# Altium schematic sheet Real Time Clock.SchDoc
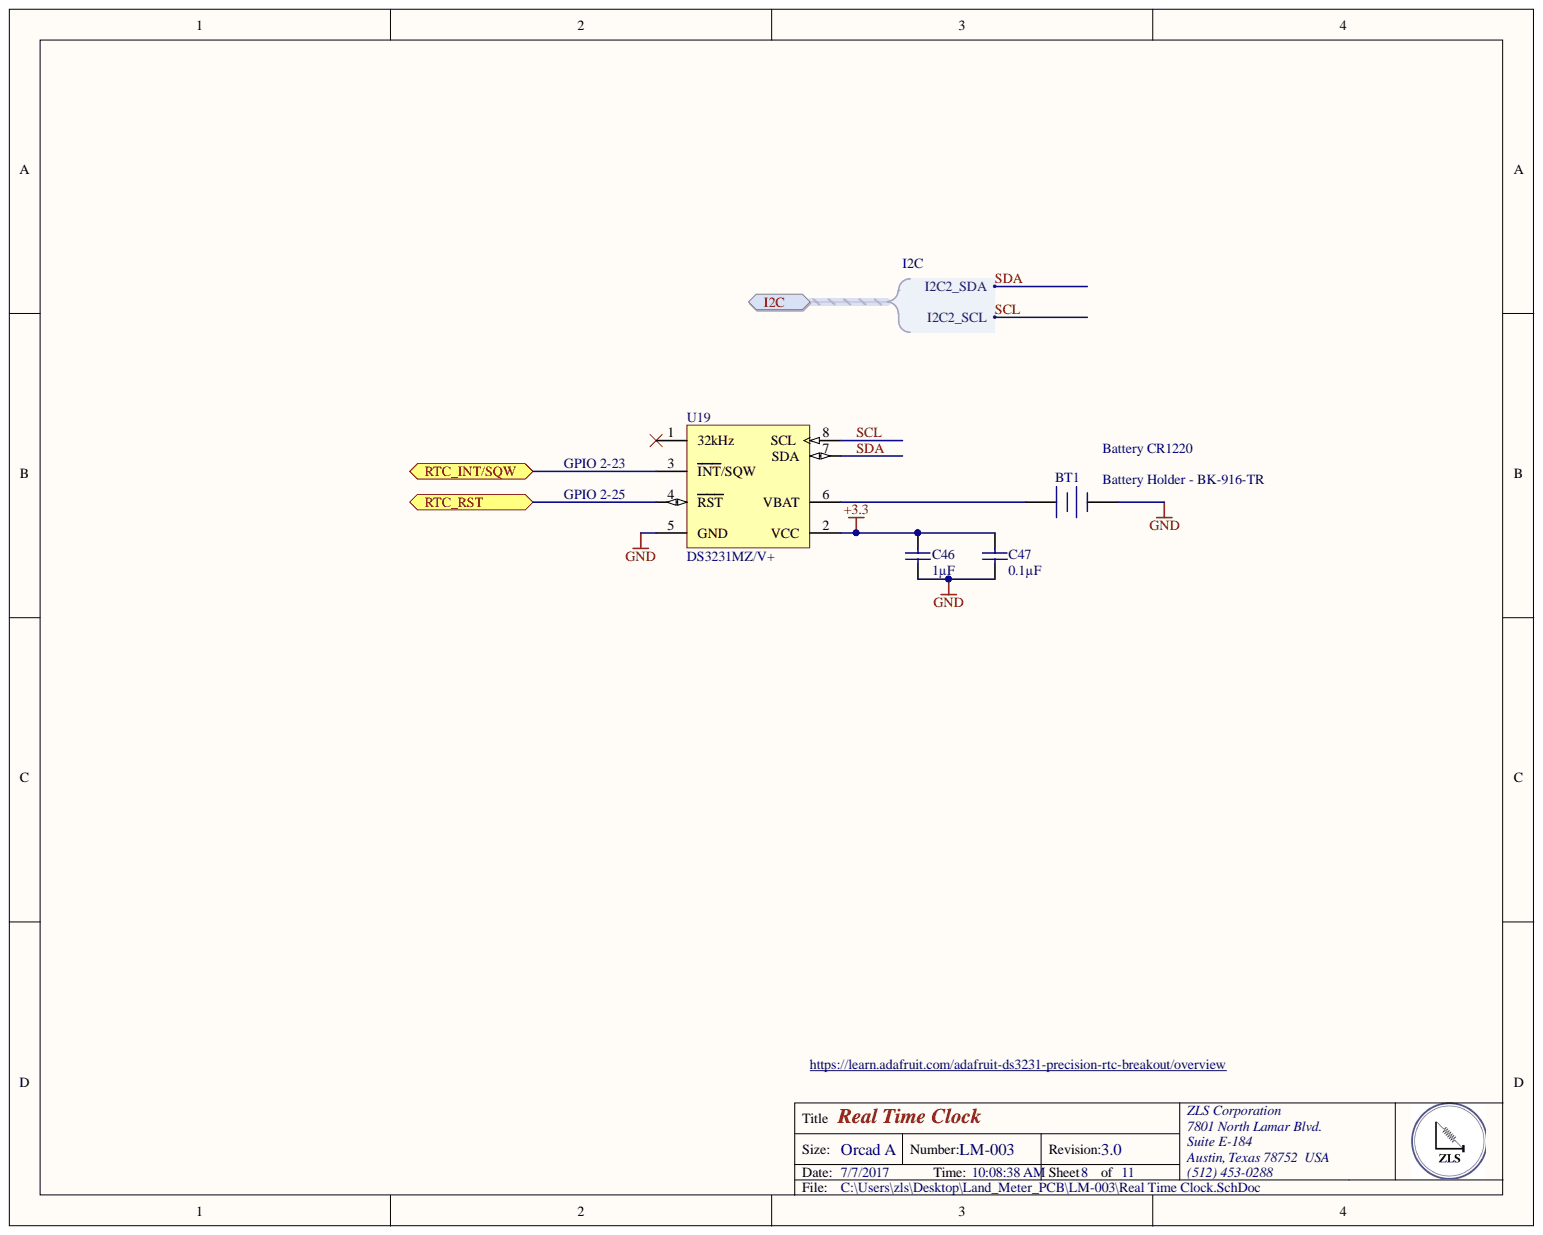
[diagram p0: Real Time Clock.SchDoc]

## Parts
BT1  Battery Holder - BK-916-TR  [BK-916-TR]
C46  Capacitance: 1µF  [CAPC0805(2012)100_M]  (hidden comment: CAP 1uF 25V 0805(2012))
C47  Capacitance: 0.1µF  [CAPC0805(2012)100_N]  (hidden comment: CAP 100nF 25V 0805(2012))
U19  DS3231MZ/V+  [S8MK+1_N]

## Ports
I2C, I2C.I2C2_SCL, I2C.I2C2_SDA, RTC_INT/SQW, RTC_RST

## Nets
+3.3: C46.1 C47.1 U19.2(VCC)
GND: BT1.2 C46.2 C47.2 U19.5(GND)
RTC_INT/SQW: U19.3(INT/SQW) ; port RTC_INT/SQW
RTC_RST: U19.4(RST) ; port RTC_RST
SCL: U19.8(SCL) ; port I2C
SDA: U19.7(SDA) ; port I2C
NetBT1_1: BT1.1 U19.6(VBAT)

Not connected: U19 1(32kHz)

## Library data of the parts
BT1 = Battery: CR1220, 1225 BATTERY RETAINER W/; Manufacturer 1: MPD (Memory Protection Devices); Battery Cell Size: Coin, 12.0mm; Battery Series: 1216, 1220, 1225; Battery Type, Function: Coin Cell, Retainer; Category 1: Battery Products; Number of Cells: 1; Component Kind: Standard; ComponentLink1Description: http://www.memoryprotectiondevices.com/datasheets/BK-916-datasheet.pdf; ComponentLink1URL: http://www.memoryprotectiondevices.com/datasheets/BK-916-datasheet.pdf; Description 1: CR1220, 1225 BATTERY RETAINER W/; Height Above Board: 0.551" (14.00mm); Library Name: Miscellaneous Devices.IntLib; Manufacturer Part Number 1: BK-916-TR; Mounting Type: PCB, Surface Mount; Operating Temperature: -; Part Status: Active; Pricing 1: 1=0.49, 10=0.449, 100=0.408, 500=0.357 (USD); RoHS 1: RoHS Compliant; Stock 1: 7084; Style: Retainer; Supplier 1: Digi-Key; Supplier Part Number 1: BK-916-CT-ND; Termination Style: SMD (SMT) Tab
C46 = CMP-1036-04754-1: CAP 1uF 25V ±10% 0805 (2012 Metric) Thickness 1mm SMD; Manufacturer: Murata Electronics North America; Manufacturer Part Number: GRM21BR71E105KA99L; Temperature Coefficient: X7R; Applications: General Purpose; Category: Capacitors; Component Kind: Standard; ComponentLink1Description: http://www.murata.com/~/media/webrenewal/support/library/catalog/products/capacitor/mlcc/c02e.ashx; ComponentLink1URL: http://www.murata.com/~/media/webrenewal/support/library/catalog/products/capacitor/mlcc/c02e.ashx; Features: -; Height - Seated (Max): -; Lead Spacing: -; Lead Style: -; Library Name: Altium Content Vault; Mounting Type: Surface Mount, MLCC; Operating Temperature: -55°C ~ 125°C; Package / Case: 0805 (2012 Metric); Packaging: Cut Tape (CT); Part Status: Active; Pricing 1: 1=0.14, 10=0.099, 100=0.0468, 1000=0.02715 (USD); Ratings: -; RoHS: RoHS Compliant; Size / Dimension: 0.079" L x 0.049" W (2.00mm x 1.25mm); Stock 1: 295334; Supplier 1: Digi-Key; Supplier Part Number 1: 490-6484-1-ND; Thickness (Max): 0.053" (1.35mm); Tolerance: ±10%; Voltage - Rated: 25V
C47 = CMP-1036-04416-1: CAP 100nF 25V -20% to +80% 0805 (2012 Metric) Thickness 1mm SMD; Manufacturer: Murata Electronics North America; Manufacturer Part Number: GRM21BR71E104KA01L; Temperature Coefficient: X7R; Tolerance: ±10%; Applications: General Purpose; Category: Capacitors; Component Kind: Standard; ComponentLink1Description: http://www.murata.com/~/media/webrenewal/support/library/catalog/products/capacitor/mlcc/c02e.ashx; ComponentLink1URL: http://www.murata.com/~/media/webrenewal/support/library/catalog/products/capacitor/mlcc/c02e.ashx; Features: -; Height - Seated (Max): -; Lead Spacing: -; Lead Style: -; Library Name: Altium Content Vault; Mounting Type: Surface Mount, MLCC; Operating Temperature: -55°C ~ 125°C; Package / Case: 0805 (2012 Metric); Packaging: Cut Tape (CT); Part Status: Active; Pricing 1: 1=0.2, 10=0.145, 100=0.0683, 500=0.04788, 1000=0.03964 (USD); Ratings: -; RoHS: RoHS Compliant; Size / Dimension: 0.079" L x 0.049" W (2.00mm x 1.25mm); Stock 1: 895391; Supplier 1: Digi-Key; Supplier Part Number 1: 490-1673-1-ND; Thickness (Max): 0.053" (1.35mm); Voltage - Rated: 25V
U19 = CMP-0254-00374-1: +/-5ppm, I2C Real-Time Clock with Internal MEMs Resonator, 8-Pin SO, -40 to 85 degC, Automotive Qualified, Pb-Free; Code_IPC: SOIC127P600-8; Code_JEDEC: MS-012-AA; Component Kind: Standard; ComponentLink1Description: Manufacturer URL; ComponentLink1URL: http://www.maxim-ic.com; ComponentLink2Description: Datasheet; ComponentLink2URL: http://datasheets.maxim-ic.com/en/ds/DS3231M.pdf; ComponentLink3Description: Package Specification; ComponentLink3URL: http://pdfserv.maxim-ic.com/package_dwgs/21-0041.PDF; DatasheetVersion: Rev 3, Dec-2011; Library Name: Altium Content Vault; PackageDescription: 8-Pin Small-Outline IC, Narrow (0.15in), 1.27 mm Pitch, SOIC (N), Pb-Free; PackageReference: S8MK+1; PackageVersion: revC, 2010
